# Altium schematic sheet UBX_NORA_B1_SCHEM_0004_Interface_MCU.SchDoc
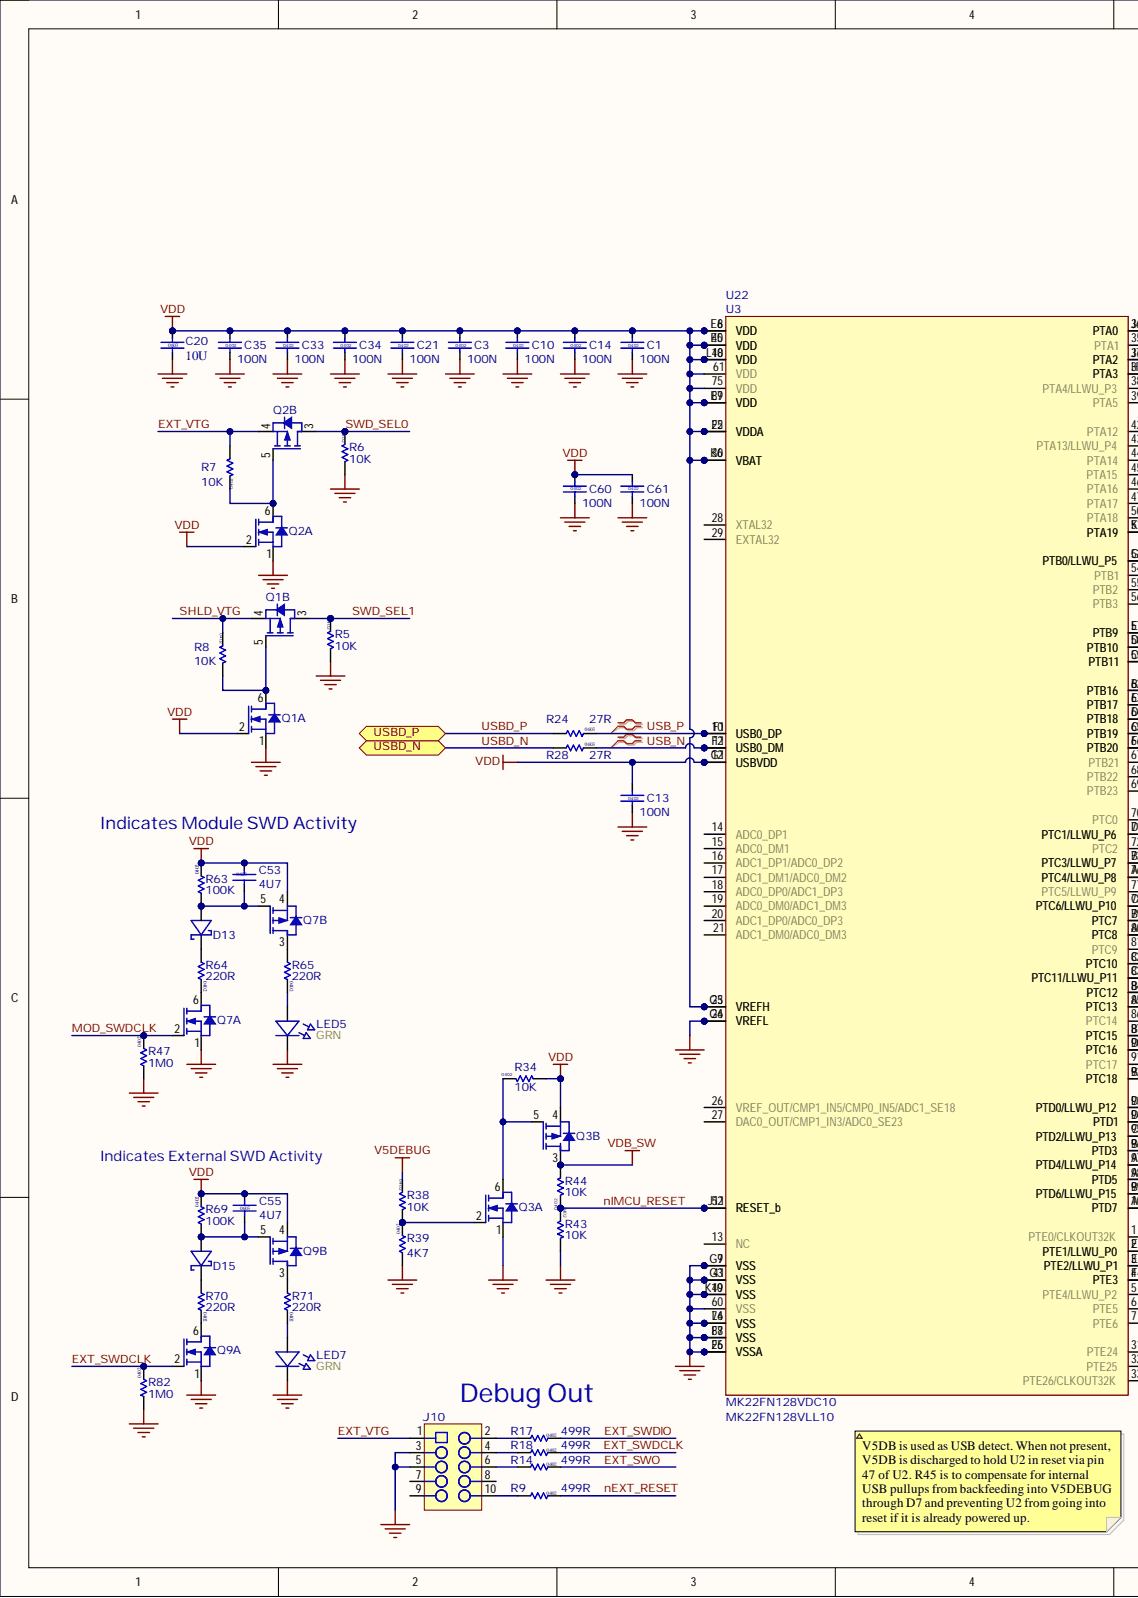
[diagram p0: UBX_NORA_B1_SCHEM_0004_Interface_MCU.SchDoc, left]
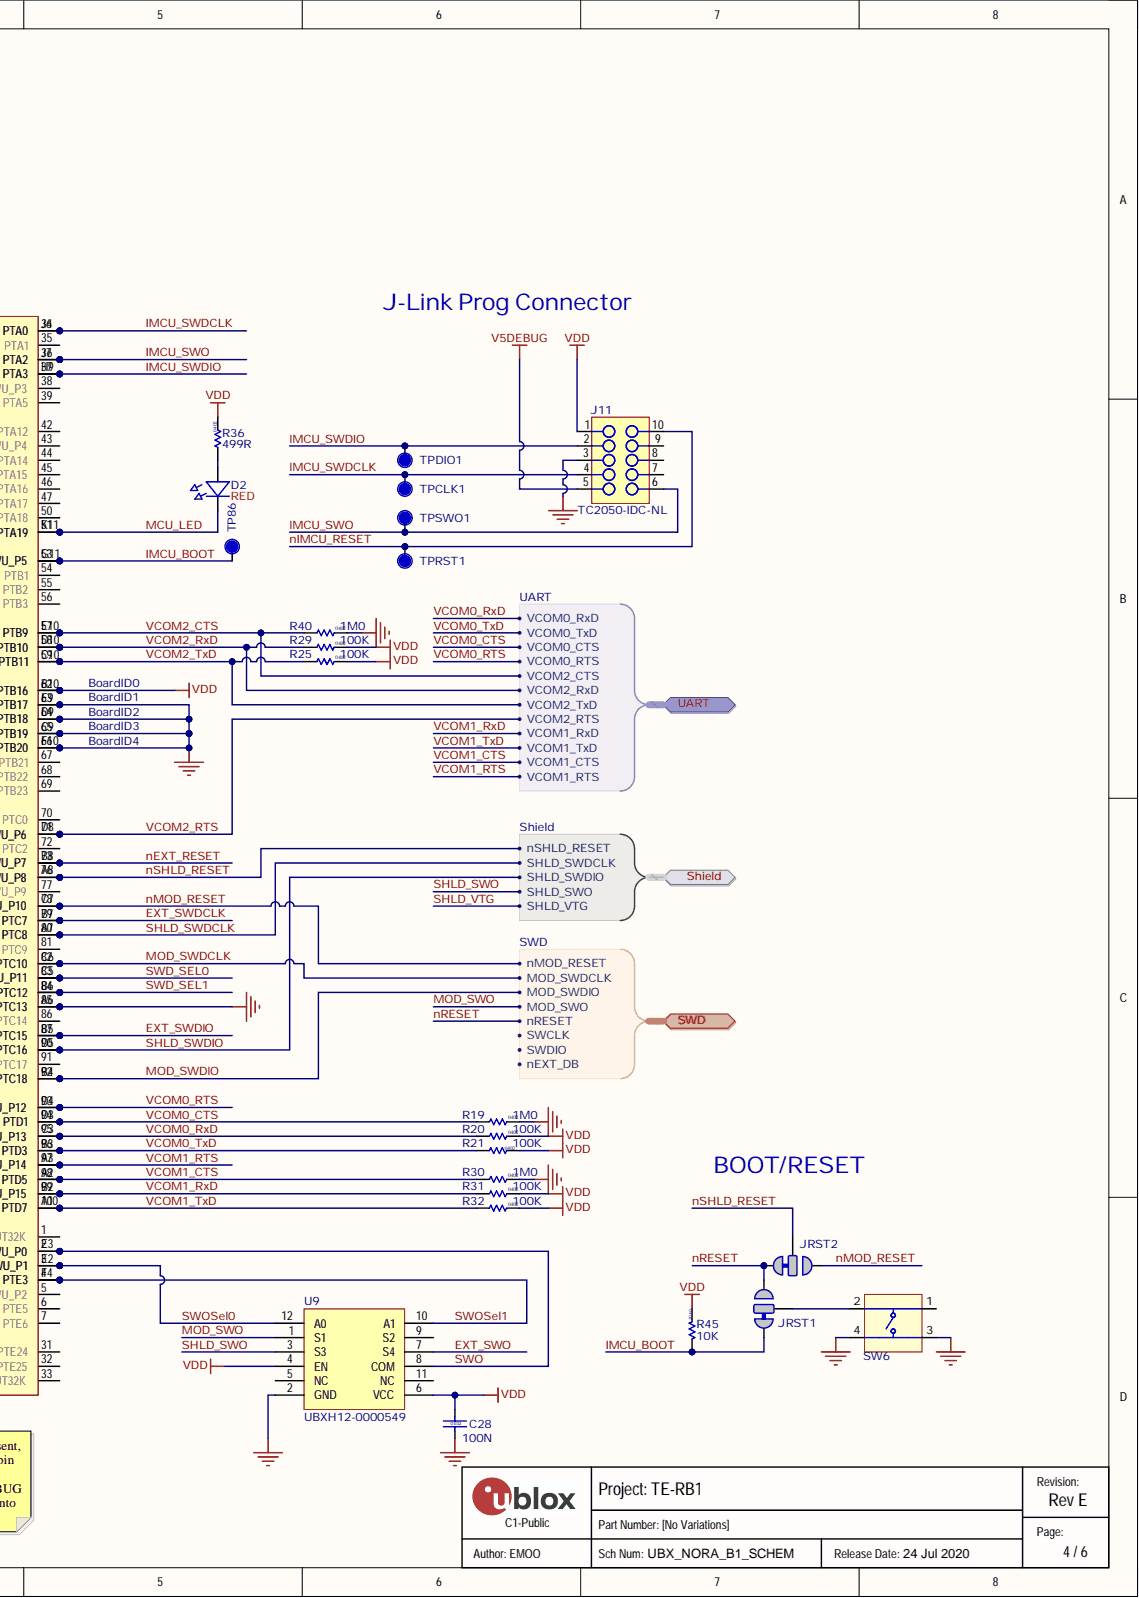
[diagram p1: UBX_NORA_B1_SCHEM_0004_Interface_MCU.SchDoc, right]

## Parts
C1  value 100N  Size: 0402  [Cap 0402 HD]  (hidden comment: UBXH01-2021002100N)
C3  value 100N  Size: 0402  [Cap 0402 HD]  (hidden comment: UBXH01-2021002100N)
C10  value 100N  Size: 0402  [Cap 0402 HD]  (hidden comment: UBXH01-2021002100N)
C13  value 100N  Size: 0402  [Cap 0402 HD]  (hidden comment: UBXH01-2021002100N)
C14  value 100N  Size: 0402  [Cap 0402 HD]  (hidden comment: UBXH01-2021002100N)
C20  value 10U  Size: 0603  [CAP 0603 HD]  (hidden comment: UBXH01-000004010U)
C21  value 100N  Size: 0402  [Cap 0402 HD]  (hidden comment: UBXH01-2021002100N)
C28  value 100N  Size: 0402  [Cap 0402 HD]  (hidden comment: UBXH01-2021002100N)
C33  value 100N  Size: 0402  [Cap 0402 HD]  (hidden comment: UBXH01-2021002100N)
C34  value 100N  Size: 0402  [Cap 0402 HD]  (hidden comment: UBXH01-2021002100N)
C35  value 100N  Size: 0402  [Cap 0402 HD]  (hidden comment: UBXH01-2021002100N)
C53  value 4U7  Size: 0603  [CAP 0603 HD]  (hidden comment: UBXH01-20020034U7)
C55  value 4U7  Size: 0603  [CAP 0603 HD]  (hidden comment: UBXH01-20020034U7)
C60  value 100N  Size: 0402  [Cap 0402 HD]  (hidden comment: UBXH01-2021002100N)
C61  value 100N  Size: 0402  [Cap 0402 HD]  (hidden comment: UBXH01-2021002100N)
D2  value RED  Color: RED  [LED_0603_RED]  (hidden comment: UBXH11-0000000)
D13  UBXH07-0000039  [SOD-523]  (value not drawn)
D15  UBXH07-0000039  [SOD-523]  (value not drawn)
J10  UBXH04-0000194  [FTSH-105-01-F-DV-K]  (value not drawn)
J11  value TC2050-IDC-NL  [TC2050-IDC-NL]  (hidden comment: UBXH00-0000101)
JRST1  UBXH00-0000083  [Solderbridge-Option]  (value not drawn)
JRST2  UBXH00-0000083  [Solderbridge-Option]  (value not drawn)
LED5  Color: GRN  [LED_0603_GREEN]  (hidden comment: UBXH11-0000001)
LED7  Color: GRN  [LED_0603_GREEN]  (hidden comment: UBXH11-0000001)
Q1  UBXH20-0000084  [SOT-563]  (value not drawn)  (parts A,B)
Q2  UBXH20-0000084  [SOT-563]  (value not drawn)  (parts A,B)
Q3  UBXH20-0000084  [SOT-563]  (value not drawn)  (parts A,B)
Q7  UBXH20-0000084  [SOT-563]  (value not drawn)  (parts A,B)
Q9  UBXH20-0000084  [SOT-563]  (value not drawn)  (parts A,B)
R5  value 10K  Size: 0402  [RES 0402 HD]  (hidden comment: UBXH18-000006510K)
R6  value 10K  Size: 0402  [RES 0402 HD]  (hidden comment: UBXH18-000006510K)
R7  value 10K  Size: 0402  [RES 0402 HD]  (hidden comment: UBXH18-000006510K)
R8  value 10K  Size: 0402  [RES 0402 HD]  (hidden comment: UBXH18-000006510K)
R9  value 499R  Size: 0402  [RES 0402 HD]  (hidden comment: UBXH18-0000269499R)
R14  value 499R  Size: 0402  [RES 0402 HD]  (hidden comment: UBXH18-0000269499R)
R17  value 499R  Size: 0402  [RES 0402 HD]  (hidden comment: UBXH18-0000269499R)
R18  value 499R  Size: 0402  [RES 0402 HD]  (hidden comment: UBXH18-0000269499R)
R19  value 1M0  Size: 0402  [RES 0402 HD]  (hidden comment: UBXH18-00081211M0)
R20  value 100K  Size: 0402  [RES 0402 HD]  (hidden comment: UBXH18-0000005100K)
R21  value 100K  Size: 0402  [RES 0402 HD]  (hidden comment: UBXH18-0000005100K)
R24  value 27R  Size: 0603  [RES 0603 HD]  (hidden comment: UBXH18-000703527R)
R25  value 100K  Size: 0402  [RES 0402 HD]  (hidden comment: UBXH18-0000005100K)
R28  value 27R  Size: 0603  [RES 0603 HD]  (hidden comment: UBXH18-000703527R)
R29  value 100K  Size: 0402  [RES 0402 HD]  (hidden comment: UBXH18-0000005100K)
R30  value 1M0  Size: 0402  [RES 0402 HD]  (hidden comment: UBXH18-00081211M0)
R31  value 100K  Size: 0402  [RES 0402 HD]  (hidden comment: UBXH18-0000005100K)
R32  value 100K  Size: 0402  [RES 0402 HD]  (hidden comment: UBXH18-0000005100K)
R34  value 10K  Size: 0402  [RES 0402 HD]  (hidden comment: UBXH18-000006510K)
R36  value 499R  Size: 0402  [RES 0402 HD]  (hidden comment: UBXH18-0000269499R)
R38  value 10K  Size: 0402  [RES 0402 HD]  (hidden comment: UBXH18-000006510K)
R39  value 4K7  Size: 0402  [RES 0402 HD]  (hidden comment: UBXH18-00002134K7)
R40  value 1M0  Size: 0402  [RES 0402 HD]  (hidden comment: UBXH18-00081211M0)
R43  value 10K  Size: 0402  [RES 0402 HD]  (hidden comment: UBXH18-000006510K)
R44  value 10K  Size: 0402  [RES 0402 HD]  (hidden comment: UBXH18-000006510K)
R45  value 10K  Size: 0402  [RES 0402 HD]  (hidden comment: UBXH18-000006510K)
R47  value 1M0  Size: 0402  [RES 0402 HD]  (hidden comment: UBXH18-00081211M0)
R63  value 100K  Size: 0402  [RES 0402 HD]  (hidden comment: UBXH18-0000005100K)
R64  value 220R  Size: 0402  [RES 0402 HD]  (hidden comment: UBXH18-0008033220R)
R65  value 220R  Size: 0402  [RES 0402 HD]  (hidden comment: UBXH18-0008033220R)
R69  value 100K  Size: 0402  [RES 0402 HD]  (hidden comment: UBXH18-0000005100K)
R70  value 220R  Size: 0402  [RES 0402 HD]  (hidden comment: UBXH18-0008033220R)
R71  value 220R  Size: 0402  [RES 0402 HD]  (hidden comment: UBXH18-0008033220R)
R82  value 1M0  Size: 0402  [RES 0402 HD]  (hidden comment: UBXH18-00081211M0)
SW6  UBXH19-0000035  [SW_TACT_SMT_6mm]  (value not drawn)
TP86  TP  [TP10R]  (no BOM)
TPCLK1  TP  [TP10R]  (no BOM)
TPDIO1  TP  [TP10R]  (no BOM)
TPRST1  TP  [TP10R]  (no BOM)
TPSWO1  TP  [TP10R]  (no BOM)
U3  MK22FN128VLL10  [TSQFP50P1600X1600X160-100N]
U9  UBXH12-0000549  [QFN-120.5P3x3-12pin]
U22  MK22FN128VDC10  [BGA0.5P8x8-121Pin]

## Ports
Shield, Shield.nSHLD_RESET, Shield.SHLD_SWDCLK, Shield.SHLD_SWDIO, Shield.SHLD_SWO, Shield.SHLD_VTG, SWD, SWD.MOD_SWDCLK, SWD.MOD_SWDIO, SWD.MOD_SWO, SWD.nEXT_DB, SWD.nMOD_RESET, SWD.nRESET, SWD.SWCLK, SWD.SWDIO, UART, UART.VCOM0_CTS, UART.VCOM0_RTS, UART.VCOM0_RxD, UART.VCOM0_TxD, UART.VCOM1_CTS, UART.VCOM1_RTS, UART.VCOM1_RxD, UART.VCOM1_TxD, UART.VCOM2_CTS, UART.VCOM2_RTS, UART.VCOM2_RxD, UART.VCOM2_TxD, USBD_N, USBD_P

## Nets
GND: C1.2 C3.2 C10.2 C13.2 C14.2 C20.2 C21.2 C28.2 C33.2 C34.2 C35.2 C60.2 C61.2 J10.3 J10.5 J11.3 LED5.1 LED7.1 Q1.1 Q2.1 Q3.1 Q7.1 Q9.1 R5.1 R6.1 R19.2 R30.2 R39.1 R40.2 R43.1 R47.1 R82.1 SW6.3 SW6.4 U3.9(VSS) U3.24(VREFL) U3.25(VSSA) U3.41(VSS) U3.49(VSS) U3.60(VSS) U3.63(PTB17) U3.64(PTB18) U3.65(PTB19) U3.66(PTB20) U3.74(VSS) U3.85(PTC13) U3.88(VSS) U9.2(GND) U22.A6(PTC13) U22.C9(PTB19) U22.D9(PTB18) U22.E9(PTB17) U22.F6(VSSA) U22.F7(VSS) U22.F10(PTB20) U22.G3(VSS) U22.G6(VREFL) U22.G7(VSS) U22.K10(VSS) U22.L6(VSS)
V5DEBUG: J11.5 R38.2
VDB_SW: Q3.3 R44.1
VDD: C1.1 C3.1 C10.1 C13.1 C14.1 C20.1 C21.1 C28.1 C33.1 C34.1 C35.1 C53.1 C55.1 C60.1 C61.1 J11.1 Q1.2 Q2.2 Q3.4 Q7.4 Q9.4 R20.2 R21.2 R25.2 R29.2 R31.2 R32.2 R34.1 R36.2 R45.2 R63.2 R69.2 U3.8(VDD) U3.12(USBVDD) U3.22(VDDA) U3.23(VREFH) U3.30(VBAT) U3.40(VDD) U3.48(VDD) U3.61(VDD) U3.62(PTB16) U3.75(VDD) U3.89(VDD) U9.4(EN) U9.6(VCC) U22.B10(PTB16) U22.E5(VDD) U22.E6(VDD) U22.E7(VDD) U22.F5(VDDA) U22.G1(USBVDD) U22.G5(VREFH) U22.K6(VBAT) U22.L10(VDD)
EXT_SWDCLK: Q9.2 R18.2 R82.2 U3.79(PTC7) U22.B7(PTC7)
EXT_SWDIO: R17.2 U3.87(PTC15) U22.B5(PTC15)
EXT_SWO: R14.2 U9.7(S4)
EXT_VTG: J10.1 Q2.4 R7.1
IMCU_BOOT: JRST1.1 R45.1 TP86.1 U3.53(PTB0/LLWU_P5) U22.G11(PTB0/LLWU_P5)
IMCU_SWDCLK: J11.4 TPCLK1.1 U3.34(PTA0) U22.J6(PTA0)
IMCU_SWDIO: J11.2 TPDIO1.1 U3.37(PTA3) U22.H9(PTA3)
IMCU_SWO: J11.6 TPSWO1.1 U3.36(PTA2) U22.J7(PTA2)
MCU_LED: D2.1 U3.51(PTA19) U22.K11(PTA19)
MOD_SWDCLK: Q7.2 R47.2 U3.82(PTC10) U22.C6(PTC10) ; port SWD
MOD_SWDIO: U3.92(PTC18) U22.B4(PTC18) ; port SWD
MOD_SWO: U9.1(S1) ; port SWD
nEXT_RESET: R9.2 U3.73(PTC3/LLWU_P7) U22.B8(PTC3/LLWU_P7)
nIMCU_RESET: J11.10 R43.2 R44.2 TPRST1.1 U3.52(RESET_b) U22.J11(RESET_b)
nMOD_RESET: JRST2.3 U3.78(PTC6/LLWU_P10) U22.C7(PTC6/LLWU_P10) ; port SWD
nRESET: JRST1.3 JRST2.1 ; port SWD
nSHLD_RESET: JRST2.2 U3.76(PTC4/LLWU_P8) U22.A8(PTC4/LLWU_P8) ; port Shield
SHLD_SWDCLK: U3.80(PTC8) U22.A7(PTC8) ; port Shield
SHLD_SWDIO: U3.90(PTC16) U22.D5(PTC16) ; port Shield
SHLD_SWO: U9.3(S3) ; port Shield
SHLD_VTG: Q1.4 R8.2 ; port Shield
SWD_SEL0: Q2.3 R6.2 U3.83(PTC11/LLWU_P11) U22.C5(PTC11/LLWU_P11)
SWD_SEL1: Q1.3 R5.2 U3.84(PTC12) U22.B6(PTC12)
SWO: U3.2(PTE1/LLWU_P0) U9.8(COM) U22.E3(PTE1/LLWU_P0)
SWOSel0: U3.3(PTE2/LLWU_P1) U9.12(A0) U22.E2(PTE2/LLWU_P1)
SWOSel1: U3.4(PTE3) U9.10(A1) U22.F4(PTE3)
USB_N: R28.2 U3.11(USB0_DM) U22.F2(USB0_DM)
USB_P: R24.2 U3.10(USB0_DP) U22.F1(USB0_DP)
USBD_N: R28.1 ; port USBD_N
USBD_P: R24.1 ; port USBD_P
VCOM0_CTS: R19.1 U3.94(PTD1) U22.D3(PTD1) ; port UART
VCOM0_RTS: U3.93(PTD0/LLWU_P12) U22.D4(PTD0/LLWU_P12) ; port UART
VCOM0_RxD: R20.1 U3.95(PTD2/LLWU_P13) U22.C3(PTD2/LLWU_P13) ; port UART
VCOM0_TxD: R21.1 U3.96(PTD3) U22.B3(PTD3) ; port UART
VCOM1_CTS: R30.1 U3.98(PTD5) U22.A2(PTD5) ; port UART
VCOM1_RTS: U3.97(PTD4/LLWU_P14) U22.A3(PTD4/LLWU_P14) ; port UART
VCOM1_RxD: R31.1 U3.99(PTD6/LLWU_P15) U22.B2(PTD6/LLWU_P15) ; port UART
VCOM1_TxD: R32.1 U3.100(PTD7) U22.A1(PTD7) ; port UART
VCOM2_CTS: R40.1 U3.57(PTB9) U22.E10(PTB9) ; port UART
VCOM2_RTS: U3.71(PTC1/LLWU_P6) U22.D8(PTC1/LLWU_P6) ; port UART
VCOM2_RxD: R29.1 U3.58(PTB10) U22.D10(PTB10) ; port UART
VCOM2_TxD: R25.1 U3.59(PTB11) U22.C10(PTB11) ; port UART
NetC53_2: C53.2 D13.A Q7.5 R63.1
NetC55_2: C55.2 D15.A Q9.5 R69.1
NetD2_2: D2.2 R36.1
NetD13_C: D13.C R64.1
NetD15_C: D15.C R70.1
NetJ10_2: J10.2 R17.1
NetJ10_4: J10.4 R18.1
NetJ10_6: J10.6 R14.1
NetJ10_10: J10.10 R9.1
NetJRST1_2: JRST1.2 SW6.2
NetLED5_2: LED5.2 R65.2
NetLED7_2: LED7.2 R71.2
NetQ1_5: Q1.5 Q1.6 R8.1
NetQ2_5: Q2.5 Q2.6 R7.2
NetQ3_2: Q3.2 R38.1 R39.2
NetQ3_5: Q3.5 Q3.6 R34.2
NetQ7_3: Q7.3 R65.1
NetQ7_6: Q7.6 R64.2
NetQ9_3: Q9.3 R71.1
NetQ9_6: Q9.6 R70.2
N00001: ; port SWD
N00002: ; port SWD
N00003: ; port SWD

Not connected: J10 7 8 9; J11 7 8 9; SW6 1; U3 1(PTE0/CLKOUT32K) 5(PTE4/LLWU_P2) 6(PTE5) 7(PTE6) 13(NC) 14(ADC0_DP1) 15(ADC0_DM1) 16(ADC1_DP1/ADC0_DP2) 17(ADC1_DM1/ADC0_DM2) 18(ADC0_DP0/ADC1_DP3) 19(ADC0_DM0/ADC1_DM3) 20(ADC1_DP0/ADC0_DP3) 21(ADC1_DM0/ADC0_DM3) 26(VREF_OUT/CMP1_IN5/CMP0_IN5/ADC1_SE18) 27(DAC0_OUT/CMP1_IN3/ADC0_SE23) 28(XTAL32) 29(EXTAL32) 31(PTE24) 32(PTE25) 33(PTE26/CLKOUT32K) 35(PTA1) 38(PTA4/LLWU_P3) 39(PTA5) 42(PTA12) 43(PTA13/LLWU_P4) 44(PTA14) 45(PTA15) 46(PTA16) 47(PTA17) 50(PTA18) 54(PTB1) 55(PTB2) 56(PTB3) 67(PTB21) 68(PTB22) 69(PTB23) 70(PTC0) 72(PTC2) 77(PTC5/LLWU_P9) 81(PTC9) 86(PTC14) 91(PTC17); U9 5(NC) 9(S2) 11(NC)

## Library data of the parts
C1 C3 C10 C13 C14 C21 C28 C33-C35 C60 C61 = UBXH01-2021002: CAP CER X5R 0402 100N 10% 10V; Manufacturer: Murata Manufacturing Company, Ltd.; Manufacturer P/N: GRM155R61A104KA01; Database Table Name: Passive - Capacitor; Editor: roland.rust; Env: GREEN; Mfg P/N Released: Yes; Mfg P/N Split: 100; Mfg P/N Status: NRND; Perferred: PREF; Permitted Use: Volume Products; Component Kind: Standard; Library Name: UBX_Parts_DB_Lib.DbLib; Manufacturer 2: Samsung Electro-Mechanics; Manufacturer P/N 2: CL05A104KP5NNN; Max Voltage: 10V; Mfg P/N Datasheet 2: https://wiki.u-blox.com/bin/view/Generic/Docs/DocumentSummary?index=28113; Mfg P/N Released 2: Yes; Mfg P/N Split 2: 0; Mfg P/N Status 2: Active; Part Number: UBXH01-2021002; Part Type: Capacitor; RefDes: C; Release Data: 2005-07-22T13:59:44; Signal Integrity: Cap Semi; Simulation: CAP; Tolerance: 10%; UBX Wiki: https://wiki.u-blox.com/bin/view/Operations/PartDetails?index=5759; Released by: roland.rust
C20 = UBXH01-0000040: CAP CER X5R 0603 10U 20% 10V; Manufacturer: Taiyo Yuden Company, Ltd.; Manufacturer P/N: LMK107BBJ106MALT; Database Table Name: Passive - Capacitor; Editor: pierpaolo.arban; Env: ROHS; Mfg P/N Released: Yes; Mfg P/N Split: 100; Mfg P/N Status: Active; Perferred: PREF; Permitted Use: Volume Products; Released by: matej.budin; Component Kind: Standard; Library Name: UBX_Parts_DB_Lib.DbLib; Manufacturer 2: Murata Manufacturing Company, Ltd.; Manufacturer 3: Taiyo Yuden Company, Ltd.; Manufacturer P/N 2: GRM188R61A106KAAL; Manufacturer P/N 3: LMK107BJ106MALTD; Max Voltage: 10V; Mfg P/N Datasheet: https://wiki.u-blox.com/bin/view/Generic/Docs/DocumentSummary?index=16905; Mfg P/N Datasheet 2: https://wiki.u-blox.com/bin/view/Generic/Docs/DocumentSummary?index=27232; Mfg P/N Datasheet 3: https://wiki.u-blox.com/bin/view/Generic/Docs/DocumentSummary?index=29392; Mfg P/N Released 2: Yes; Mfg P/N Released 3: Yes; Mfg P/N Split 2: 0; Mfg P/N Split 3: 0; Mfg P/N Status 2: Active; Mfg P/N Status 3: NRND; Part Number: UBXH01-0000040; Part Type: Capacitor; RefDes: C; Release Data: 2013-01-10T11:54:39; Signal Integrity: Cap Semi; Simulation: CAP; Tolerance: 20%; UBX Wiki: https://wiki.u-blox.com/bin/view/Operations/PartDetails?index=16909
C53 C55 = UBXH01-2002003: CAP CER X5R 0603 4U7 10% 6.3V; Manufacturer: Murata Manufacturing Company, Ltd.; Manufacturer P/N: GRM188R61C475KE11; Database Table Name: Passive - Capacitor; Editor: philippe.largier; Env: GREEN; Mfg P/N Released: Yes; Mfg P/N Split: 100; Mfg P/N Status: Active; Perferred: PREF; Permitted Use: Volume Products; Released by: roland.rust; Component Kind: Standard; Library Name: UBX_Parts_DB_Lib.DbLib; Manufacturer 2: Murata Manufacturing Company, Ltd.; Manufacturer 3: Samsung Electro-Mechanics; Manufacturer P/N 2: GRM188R61E475KE11; Manufacturer P/N 3: CL10A475KO8NNN; Max Voltage: 6.3V; Mfg P/N Datasheet: https://wiki.u-blox.com/bin/view/Generic/Docs/DocumentSummary?index=30154; Mfg P/N Datasheet 2: https://wiki.u-blox.com/bin/view/Generic/Docs/DocumentSummary?index=30500; Mfg P/N Datasheet 3: https://wiki.u-blox.com/bin/view/Generic/Docs/DocumentSummary?index=28177; Mfg P/N Released 2: Yes; Mfg P/N Released 3: Yes; Mfg P/N Split 2: 0; Mfg P/N Split 3: 0; Mfg P/N Status 2: Active; Mfg P/N Status 3: NRND; Part Number: UBXH01-2002003; Part Type: Capacitor; RefDes: C; Release Data: 2016-02-02T16:39:45; Signal Integrity: Cap Semi; Simulation: CAP; Tolerance: 10%; UBX Wiki: https://wiki.u-blox.com/bin/view/Operations/PartDetails?index=5742
D2 = UBXH11-0000000: LED SMD 0603 ULTRABRIGHT LITE-ON LTST-C190KRKT RED 30mA; Manufacturer: Lite-on Technology Corporation; Database Table Name: Discrete - LED; Editor: massimo.vlacci; Env: ROHS; Mfg P/N Released: Yes; Mfg P/N Split: 100; Mfg P/N Status: Active; Permitted Use: Support Products; Released by: sandro.sestan; Component Kind: Standard; Library Name: UBX_Parts_DB_Lib.DbLib; Manufacturer P/N: LTST-C190KRKT; Max Current: 30mA; Mfg P/N Datasheet: https://wiki.u-blox.com/bin/view/Generic/Docs/DocumentSummary?index=16221; Part Number: UBXH11-0000000; Part Type: LED; Perferred: N/A; RefDes: DS; Release Data: 2009-12-03T15:33:11; Size: 0603; UBX Wiki: https://wiki.u-blox.com/bin/view/Operations/PartDetails?index=7900
D13 D15 = UBXH07-0000039: SCHOTTKY BARRIER DIODE 30V 200mA; Manufacturer: ON Semiconductor; Manufacturer P/N: RB521S30T1G; Database Table Name: Discrete - Diode; Editor: matej.budin; Env: ROHS; Mfg P/N Released: Yes; Mfg P/N Split: 100; Mfg P/N Status: Active; Perferred: PREF; Permitted Use: Volume Products; Released by: pierpaolo.arban; Value: RB521S30T1G; Component Kind: Standard; Library Name: UBX_Parts_DB_Lib.DbLib; Max Current: 200mA; Max Voltage: 30V; Mfg P/N Datasheet: https://wiki.u-blox.com/bin/view/Generic/Docs/DocumentSummary?index=22755; Part Number: UBXH07-0000039; Part Type: Diode; RefDes: D; Release Data: 2015-06-18T10:04:48; UBX Wiki: https://wiki.u-blox.com/bin/view/Operations/PartDetails?index=22753
J10 = UBXH04-0000194: 2-ROW SMD-PCB CONN 50MIL GRID 10 -PIN 0.41MM SQUARE 4.0MM KEYED; Manufacturer: Samtec; Manufacturer P/N: FTSH-105-01-L-DV-K; Database Table Name: Electromechanical - Connector; Editor: par.hakansson; Env: ROHS; Mfg P/N Released: Yes; Mfg P/N Split: 100; Mfg P/N Status: Active; Perferred: PREF; Permitted Use: Volume Products; Released by: adam.johansson; Value: FTSH-105-01-L-DV-K; Component Kind: Standard; Library Name: UBX_Parts_DB_Lib.DbLib; Max Operating Temp: 85°C; Mfg P/N Datasheet: https://wiki.u-blox.com/bin/view/Generic/Docs/DocumentSummary?index=20824; Min Operating Temp: -40°C; Part Number: UBXH04-0000194; Part Type: Connector; RefDes: J; Release Data: 2015-11-06T11:35:39; UBX Wiki: https://wiki.u-blox.com/bin/view/Operations/PartDetails?index=20823
J11 = UBXH00-0000101: TAG-CONNECT TC2050-IDC-NL 10-PIN PROBE PROGRAMMING HEADER NO LEGS TC2050-IDC-NL; Database Table Name: Printed Circuit Element - Connector; Editor: eric.moog; Env: ROHS; Mfg P/N Split: 100; Mfg P/N Status: Active; Perferred: PREF; Permitted Use: Support Products; Component Kind: Standard; Library Name: UBX_Parts_DB_Lib.DbLib; Manufacturer: Tag-Connect; Manufacturer P/N: TC2050-IDC-NL; Max Operating Temp: 155°C; Mfg P/N Datasheet: https://wiki.u-blox.com/bin/view/Generic/Docs/DocumentSummary?index=38952; Mfg P/N Released: No; Min Operating Temp: -55°C; Part Number: UBXH00-0000101; Part Type: Connector; RefDes: J; UBX Wiki: https://wiki.u-blox.com/bin/view/Operations/PartDetails?index=38953
JRST1 JRST2 = UBXH00-0000083: SOLDERBRIDGE-OPTION; Database Table Name: Printed Circuit Element - Connector; Editor: aneeb.sohail; Env: GREEN; Perferred: PREF; Permitted Use: Volume Products; Component Kind: Net Tie; Library Name: UBX_Parts_DB_Lib.DbLib; Max Operating Temp: 155°C; Min Operating Temp: -55°C; Part Number: UBXH00-0000083; Part Type: Connector; RefDes: J; UBX Wiki: https://wiki.u-blox.com/bin/view/Operations/PartDetails?index=36362
LED5 LED7 = UBXH11-0000001: LED SMD 0603 GREEN 2.5V 20mA; Manufacturer: Kingbright; Manufacturer P/N: APT1608SGC; Database Table Name: Discrete - LED; Editor: gianni.falleroni; Env: ROHS; Mfg P/N Released: Yes; Mfg P/N Split: 50; Mfg P/N Status: Active; Permitted Use: Support Products; Released by: alex.claville; Component Kind: Standard; Library Name: UBX_Parts_DB_Lib.DbLib; Manufacturer 2: Lite-on Technology Corporation; Manufacturer P/N 2: LTST-C190KGKT; Max Current: 20mA; Max Voltage: 2.5V; Mfg P/N Datasheet: https://wiki.u-blox.com/bin/view/Generic/Docs/DocumentSummary?index=37527; Mfg P/N Datasheet 2: https://wiki.u-blox.com/bin/view/Generic/Docs/DocumentSummary?index=16220; Mfg P/N Released 2: Yes; Mfg P/N Split 2: 50; Mfg P/N Status 2: Active; Part Number: UBXH11-0000001; Part Type: LED; Perferred: N/A; RefDes: DS; Release Data: 2022-09-27T14:26:54; Size: 0603; UBX Wiki: https://wiki.u-blox.com/bin/view/Operations/PartDetails?index=7902; Value: GREEN
Q1-Q3 Q7 Q9 = UBXH20-0000084: SMALL SIGNAL COMPLEMENTARY W/ ESD PROTECTION MOSFET SOT-563-6 PACKAGE; Manufacturer: ON Semiconductor; Manufacturer P/N: NTZD3155CT1G; Database Table Name: Discrete - Transistor; Editor: pierpaolo.arban; Env: ROHS; Mfg P/N Released: Yes; Mfg P/N Split: 100; Mfg P/N Status: Active; Perferred: PREF; Permitted Use: Support Products; Released by: matej.budin; Value: NTZD3155CT1G; Component Kind: Standard; Library Name: UBX_Parts_DB_Lib.DbLib; Max Operating Temp: 155°C; Mfg P/N Datasheet: https://wiki.u-blox.com/bin/view/Generic/Docs/DocumentSummary?index=9037; Min Operating Temp: -55°C; Part Number: UBXH20-0000084; Part Type: Transistor; RefDes: Q; Release Data: 2023-01-26T11:59:16; UBX Wiki: https://wiki.u-blox.com/bin/view/Operations/PartDetails?index=37717
R5-R8 R34 R38 R43-R45 = UBXH18-0000065: RES THICK FILM CHIP 0402 10K 1% 63mW; Manufacturer: KOA; Manufacturer P/N: RK73H1ETTP1002F; Database Table Name: Passive - Resistor; Editor: erian.bezhani; Env: ROHS; Mfg P/N Released: Yes; Mfg P/N Split: 100; Mfg P/N Status: Active; Perferred: PREF; Permitted Use: Volume Products; Released by: massimo.vlacci; Component Kind: Standard; Library Name: UBX_Parts_DB_Lib.DbLib; Max Power: 63mW; Mfg P/N Datasheet: https://wiki.u-blox.com/bin/view/Generic/Docs/DocumentSummary?index=8222; Part Number: UBXH18-0000065; Part Type: Resistor; RefDes: R; Release Data: 2012-06-08T18:02:21; Signal Integrity: Res; Simulation: RESISTOR; Tolerance: 1%; UBX Wiki: https://wiki.u-blox.com/bin/view/Operations/PartDetails?index=16837
R9 R14 R17 R18 R36 = UBXH18-0000269: RES THICK FILM CHIP 0402 499R 1% 100mW; Manufacturer: KOA; Manufacturer P/N: RK73H1ETTP4990F; Database Table Name: Passive - Resistor; Editor: pierpaolo.arban; Env: ROHS; Mfg P/N Released: No; Mfg P/N Split: 100; Mfg P/N Status: Active; Perferred: PREF; Permitted Use: Volume Products; Component Kind: Standard; Library Name: UBX_Parts_DB_Lib.DbLib; Max Operating Temp: 125°C; Max Power: 100mW; Mfg P/N Datasheet: https://wiki.u-blox.com/bin/view/Generic/Docs/DocumentSummary?index=8222; Min Operating Temp: -55°C; Part Number: UBXH18-0000269; Part Type: Resistor; RefDes: R; Signal Integrity: Res; Simulation: RESISTOR; Tolerance: 1%; UBX Wiki: https://wiki.u-blox.com/bin/view/Operations/PartDetails?index=25384
R19 R30 R40 R47 R82 = UBXH18-0008121: RES THICK FILM CHIP 0402 1M0 5% 63mW; Manufacturer: KOA; Manufacturer P/N: RK73B1ETTP105J; Database Table Name: Passive - Resistor; Editor: daniel.hurni; Env: ROHS; Mfg P/N Released: Yes; Mfg P/N Split: 100; Mfg P/N Status: Active; Perferred: PREF; Permitted Use: Volume Products; Released by: doris.zahnd; Component Kind: Standard; Library Name: UBX_Parts_DB_Lib.DbLib; Max Operating Temp: 125°C; Max Power: 63mW; Mfg P/N Datasheet: https://wiki.u-blox.com/bin/view/Generic/Docs/DocumentSummary?index=4543; Min Operating Temp: -55°C; Part Number: UBXH18-0008121; Part Type: Resistor; RefDes: R; Release Data: 2009-12-01T17:35:03; Signal Integrity: Res; Simulation: RESISTOR; Tolerance: 5%; UBX Wiki: https://wiki.u-blox.com/bin/view/Operations/PartDetails?index=7052
R20 R21 R25 R29 R31 R32 R63 R69 = UBXH18-0000005: RES THICK FILM CHIP 0402 100K 1% 63mW; Manufacturer: KOA; Manufacturer P/N: RK73H1ETTP1003F; Database Table Name: Passive - Resistor; Editor: dario.antonaz; Env: ROHS; Mfg P/N Released: Yes; Mfg P/N Split: 100; Mfg P/N Status: Active; Permitted Use: Volume Products; Released by: sandro.sestan; Component Kind: Standard; Library Name: UBX_Parts_DB_Lib.DbLib; Manufacturer 2: ROHM; Manufacturer P/N 2: MCR01MZPF1003; Max Power: 63mW; Mfg P/N Datasheet: https://wiki.u-blox.com/bin/view/Generic/Docs/DocumentSummary?index=8222; Mfg P/N Datasheet 2: https://wiki.u-blox.com/bin/view/Generic/Docs/DocumentSummary?index=8186; Mfg P/N Released 2: Yes; Mfg P/N Split 2: 0; Mfg P/N Status 2: Active; Part Number: UBXH18-0000005; Part Type: Resistor; Perferred: N/A; RefDes: R; Release Data: 2009-11-30T11:05:22; Signal Integrity: Res; Simulation: RESISTOR; Tolerance: 1%; UBX Wiki: https://wiki.u-blox.com/bin/view/Operations/PartDetails?index=8114
R24 R28 = UBXH18-0007035: RES THICK FILM CHIP 0603 27R 5% 100mW; Manufacturer: YAGEO; Manufacturer P/N: RC0603JR-0727RL; Database Table Name: Passive - Resistor; Editor: martin.frauchiger; Env: ROHS; Mfg P/N Released: Yes; Mfg P/N Split: 100; Mfg P/N Status: Active; Perferred: PREF; Permitted Use: Volume Products; Component Kind: Standard; Library Name: UBX_Parts_DB_Lib.DbLib; Max Power: 100mW; Part Number: UBXH18-0007035; Part Type: Resistor; RefDes: R; Release Data: 2005-07-14T10:55:51; Signal Integrity: Res; Simulation: RESISTOR; Tolerance: 5%; UBX Wiki: https://wiki.u-blox.com/bin/view/Operations/PartDetails?index=6657; Released by: martin.frauchiger
R39 = UBXH18-0000213: RES THICK FILM CHIP 0402 4K7 1% 63mW; Manufacturer: KOA; Manufacturer P/N: RK73H1ETTP4701F; Database Table Name: Passive - Resistor; Editor: michael.hein; Env: ROHS; Mfg P/N Released: Yes; Mfg P/N Split: 100; Mfg P/N Status: Active; Perferred: PREF; Permitted Use: Volume Products; Released by: vasyl.dykyy; Automotive: Yes; Component Kind: Standard; Library Name: UBX_Parts_DB_Lib.DbLib; Max Power: 63mW; Mfg P/N Datasheet: https://wiki.u-blox.com/bin/view/Generic/Docs/DocumentSummary?index=8222; Part Number: UBXH18-0000213; Part Type: Resistor; RefDes: R; Release Data: 2015-10-02T11:47:33; Signal Integrity: Res; Simulation: RESISTOR; Tolerance: 1%; UBX Wiki: https://wiki.u-blox.com/bin/view/Operations/PartDetails?index=23300
R64 R65 R70 R71 = UBXH18-0008033: RES THICK FILM CHIP 0402 220R 5% 100mW; Manufacturer: ROHM; Manufacturer P/N: MCR01MZPJ221; Database Table Name: Passive - Resistor; Editor: roland.rust; Env: ROHS; Mfg P/N Released: Yes; Mfg P/N Split: 100; Mfg P/N Status: Active; Perferred: PREF; Permitted Use: Volume Products; Component Kind: Standard; Library Name: UBX_Parts_DB_Lib.DbLib; Manufacturer 2: KOA; Manufacturer 3: YAGEO; Manufacturer P/N 2: RK73B1ETTP221J; Manufacturer P/N 3: 2322_705_87221_L; Max Power: 100mW; Mfg P/N Released 2: Yes; Mfg P/N Released 3: Yes; Mfg P/N Split 2: 0; Mfg P/N Split 3: 0; Mfg P/N Status 2: Active; Mfg P/N Status 3: NRND; Part Number: UBXH18-0008033; Part Type: Resistor; RefDes: R; Release Data: 2009-04-24T14:36:39; Signal Integrity: Res; Simulation: RESISTOR; Tolerance: 5%; UBX Wiki: https://wiki.u-blox.com/bin/view/Operations/PartDetails?index=6914; Released by: roland.rust
SW6 = UBXH19-0000035: TACTILE SWITCH SPST SINGLE SMD; Manufacturer: C&K; Manufacturer P/N: PTS645SM43SMTR92LFS; Database Table Name: Electromechanical - Switch; Editor: enric.suchanek; Env: ROHS; Mfg P/N Released: No; Mfg P/N Split: 100; Mfg P/N Status: Active; Perferred: PREF; Permitted Use: Internal Use; Released by: charlotte.biber; Value: PTS645SM43SMTR92LFS; Component Kind: Standard; Library Name: UBX_Parts_DB_Lib.DbLib; Max Operating Temp: 85°C; Mfg P/N Datasheet: https://wiki.u-blox.com/bin/view/Generic/Docs/DocumentSummary?index=32053; Min Operating Temp: -40°C; Part Number: UBXH19-0000035; Part Type: Switch; RefDes: S; Release Data: 2021-08-24T16:41:59; UBX Wiki: https://wiki.u-blox.com/bin/view/Operations/PartDetails?index=32051
TP86 TPCLK1 TPDIO1 TPRST1 TPSWO1 = UBXH00-0000096: TESTPOINT 1MM CIRCULAR; Database Table Name: Printed Circuit Element - Testpoint; Editor: eric.moog; Perferred: PREF; Permitted Use: Volume Products; Env: N/A; Library Name: UBX_Parts_DB_Lib.DbLib; Max Operating Temp: 125°C; Min Operating Temp: -55°C; Part Number: UBXH00-0000096; Part Type: Testpoint; RefDes: TP; UBX Wiki: https://wiki.u-blox.com/bin/view/Operations/PartDetails?index=38784
U3 = UBXH05-0000157: MICROCONTROLLER IC 32-BIT 100MHZ 128KB (128K X 8) FLASH QFN; Manufacturer: NXP; Part number IPS: 00000001366; Artikkelnr Noca: N.A.; Database Table Name: IC - Digital/Mixed Signal ASIC; Editor: tobias.naumann; Env: ROHS; Mfg P/N Released: No; Mfg P/N Split: 100; Mfg P/N Status: Active; Permitted Use: Internal Use; Released by: thai.nguyen-xuan; Component Kind: Standard; ComponentLink1Description: Datasheet; ComponentLink1URL: http://cache.freescale.com/files/microcontrollers/doc/data_sheet/K22P121M100SF9.pdf; ComponentLink2Description: Supplier link; ComponentLink2URL: https://cache.nxp.com/docs/en/package-information/98ASS23308W.pdf; Footprint Doc: LQFP-100; LatestRevisionDate: 2019-03-01; Library Name: UBX_Parts_DB_Lib.DbLib; Manufacturer P/N: MK22FN128VLL10; Manufacturer Part Number: MK22FN128VLL10; Max Operating Temp: 105°C; Mfg P/N Datasheet: https://wiki.u-blox.com/bin/view/Generic/Docs/DocumentSummary?index=36069; Min Operating Temp: -40°C; Part Number: UBXH05-0000157; Part Type: Digital/Mixed Signal ASIC; Perferred: N/A; Pin Count: 100; Published: 2018-05-10; RefDes: U; Release Data: 2022-01-03T08:47:21; Supplier 1: Digi-Key; Supplier 2: Mouser; Supplier Part Number 1: MK22FN128VLL10-ND; Supplier Part Number 2: 841-MK22FN128VLL10; UBX Wiki: https://wiki.u-blox.com/bin/view/Operations/PartDetails?index=36072; Value: MK22FN128VLL10; Publisher: Nordic Semiconductor
U9 = UBXH12-0000549: ANALOG SWITCH SP4T 1 CIRCUIT 2.5OHM 12-QFN (3X3) 5.5V; Manufacturer: Vishay; Manufacturer 1: Vishay Siliconix; Manufacturer P/N: DG2034EDN-T1-GE4; Artikkelnr Noca: N.A.; Database Table Name: IC - Switch; Editor: eric.moog; Env: ROHS; Mfg P/N Released: No; Mfg P/N Split: 100; Mfg P/N Status: Active; Perferred: PREF; Permitted Use: Support Products; Value: DG2034EDN-T1-GE4; Component Kind: Standard; ComponentLink1Description: Datasheet; ComponentLink1URL: http://www.vishay.com/docs/73172/dg2034e.pdf; ComponentLink2Description: Supplier link; Footprint Doc: 12-QFN; LatestRevisionDate: 2018-05-30; Library Name: UBX_Parts_DB_Lib.DbLib; Manufacturer Part Number 1: DG2034EDN-T1-GE4; Max Operating Temp: 85°C; Max Voltage: 5.5V; Mfg P/N Datasheet: https://wiki.u-blox.com/bin/view/Generic/Docs/DocumentSummary?index=38923; Min Operating Temp: -40°C; Part Number: UBXH12-0000549; Part number IPS: N.A.; Part Type: Switch; Pin Count: 12; Published: 2018-05-30; RefDes: U; Supplier 1: Digi-Key; Supplier 2: Mouser; Supplier Part Number 1: DG2034EDN-T1-GE4CT-ND; Supplier Part Number 2: 78-DG2034EDN-T1-GE4; UBX Wiki: https://wiki.u-blox.com/bin/view/Operations/PartDetails?index=38924; Publisher: Nordic Semiconductor
U22 = UBXH05-0000174: MICROCONTROLLER IC 32-BIT 100MHZ 128KB (128K X 8) FLASH BGA_EVK-NORA-B1; Manufacturer: NXP; Body Size: 8mm x 8mm; Component Mounting Type: SMT; Core Architecture: Cortex-M4F; Core Bits: 32 Bit; Database Table Name: IC - Digital/Mixed Signal ASIC; Editor: eric.moog; Env: ROHS; Item_Phase: Unreleased; Max Oper Temp in C: 105; MFG Package: XFBGA-121; Min Oper Temp in C: -40; Perferred: PREF; Permitted Use: Support Products; RAM Size: 24kB; SMT Pitch: 0.65mm; Use in new designs: Yes; Voltage: 3.6V; Component Kind: Standard; ComponentLink1Description: Datasheet; ComponentLink1URL: http://cache.freescale.com/files/microcontrollers/doc/data_sheet/K22P121M100SF9.pdf; ComponentLink2Description: Supplier link; ComponentLink2URL: https://cache.nxp.com/docs/en/package-information/98ASS23308W.pdf; Flash Size: 128kB; Footprint Doc: XFBGA-121; Library Name: UBX_Parts_DB_Lib.DbLib; Manufacturer P/N: MK22FN128VDC10; Manufacturer Part Number: MK22FN128VDC10; Max Operating Temp: 105°C; Min Operating Temp: -40°C; Package Type: XFBGA; Part Name: MK22FN128VDC10; Part Number: UBXH05-0000174; Part Type: Digital/Mixed Signal ASIC; Part_Type: Microcontroller; Pin Count: 121; Pins: 100; RefDes: U; Revision: 00; UBX Wiki: https://wiki.u-blox.com/bin/view/Operations/PartDetails?index=38965; Value: MK22FN128VDC10
